# Altium schematic sheet debugging_board_PFC161.SchDoc(debugging_board_PFC161)
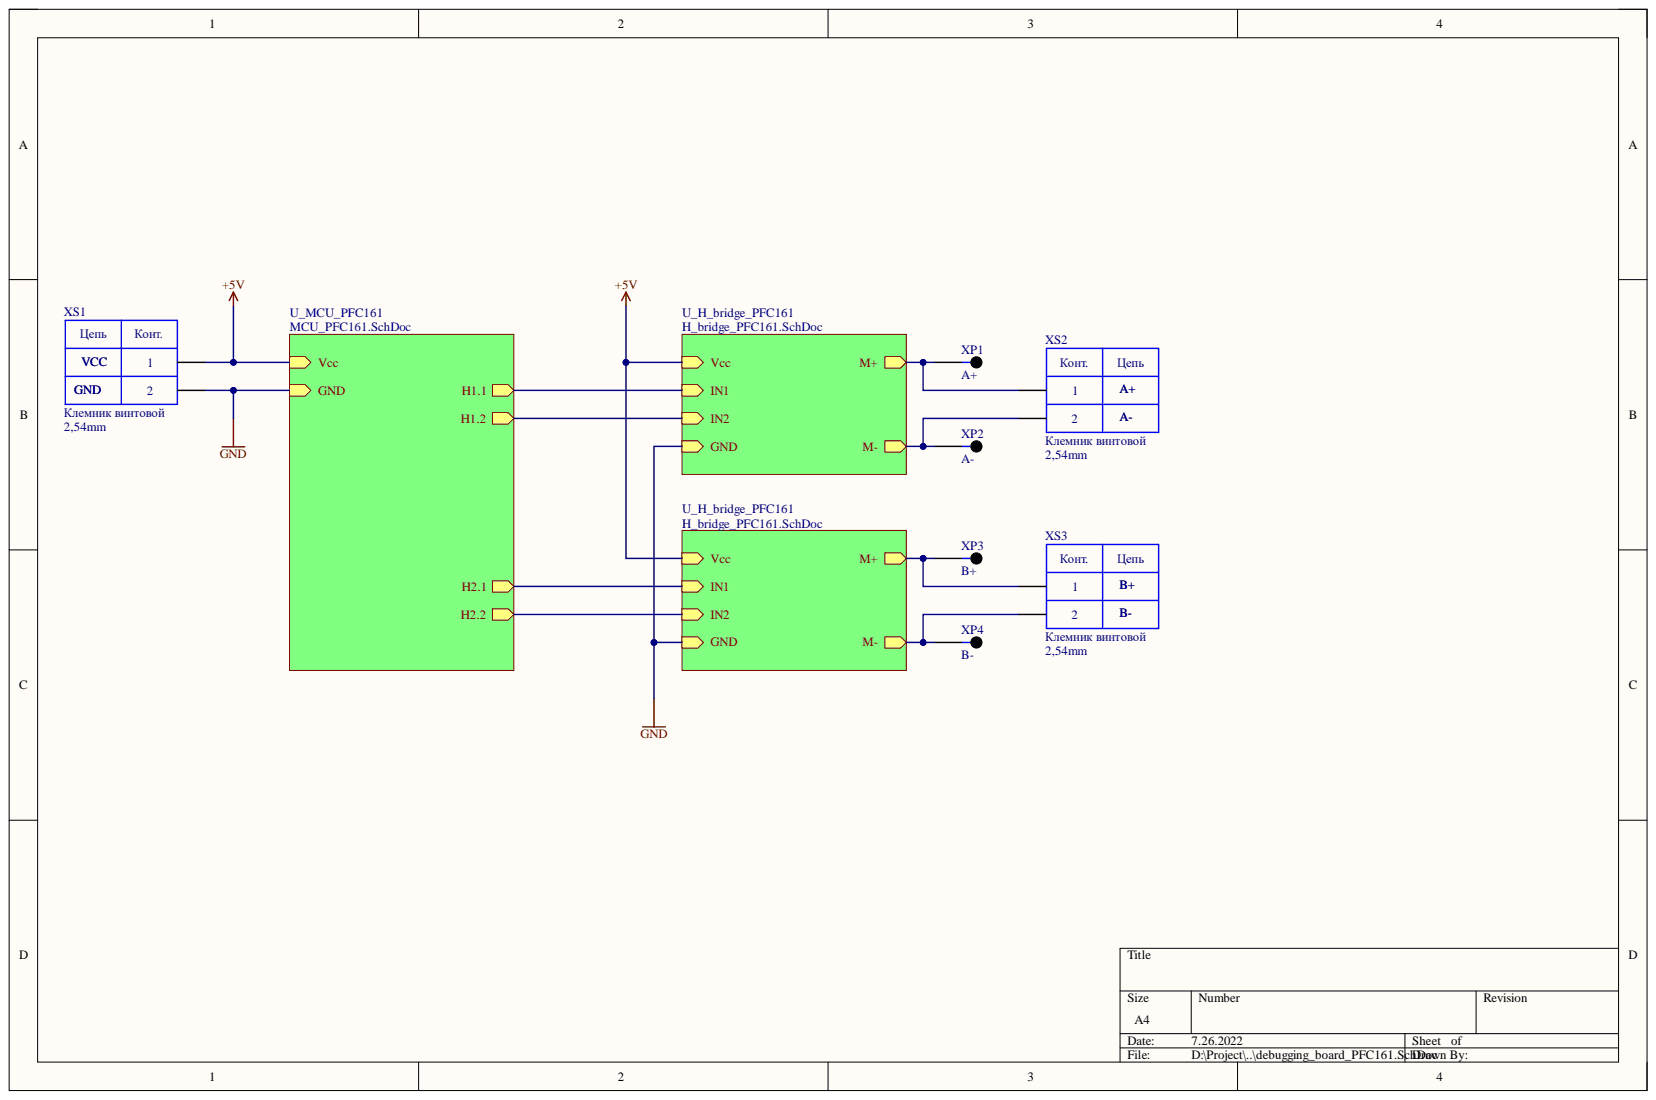
[diagram p0: debugging_board_PFC161.SchDoc]

## Parts
XP1  A+  [XP_solder]
XP2  A-  [XP_solder]
XP3  B+  [XP_solder]
XP4  B-  [XP_solder]
XS1  Клемник винтовой  Package: 2,54mm  [XS_2x2.54MM]
XS2  Клемник винтовой  Package: 2,54mm  [XS_2x2.54MM]
XS3  Клемник винтовой  Package: 2,54mm  [XS_2x2.54MM]

## Nets
+5V: XS1.1
GND: XS1.2
NetXP1_1: XP1.1 XS2.1
NetXP2_1: XP2.1 XS2.2
NetXP3_1: XP3.1 XS3.1
NetXP4_1: XP4.1 XS3.2
H1.1:
H1.2:
H2.1:
H2.2:

## Library data of the parts
XP1-XP4 = XP_solder: Component Kind: Standard; Library Name: MechanikLibrary.SchLib
XS1-XS3 = Клемник винтовой 2-контактный, 2,54мм: ValueBOM: 308-021-14, Клеммник винтовой, 2-контактный, 2.54мм, прямой; Component Kind: Standard; Type: XS; Value: Клемник винтовой; Library Name: MechanikLibrary.SchLib
